# Altium schematic sheet Power_Regulation.SchDoc(Power_Regulation)
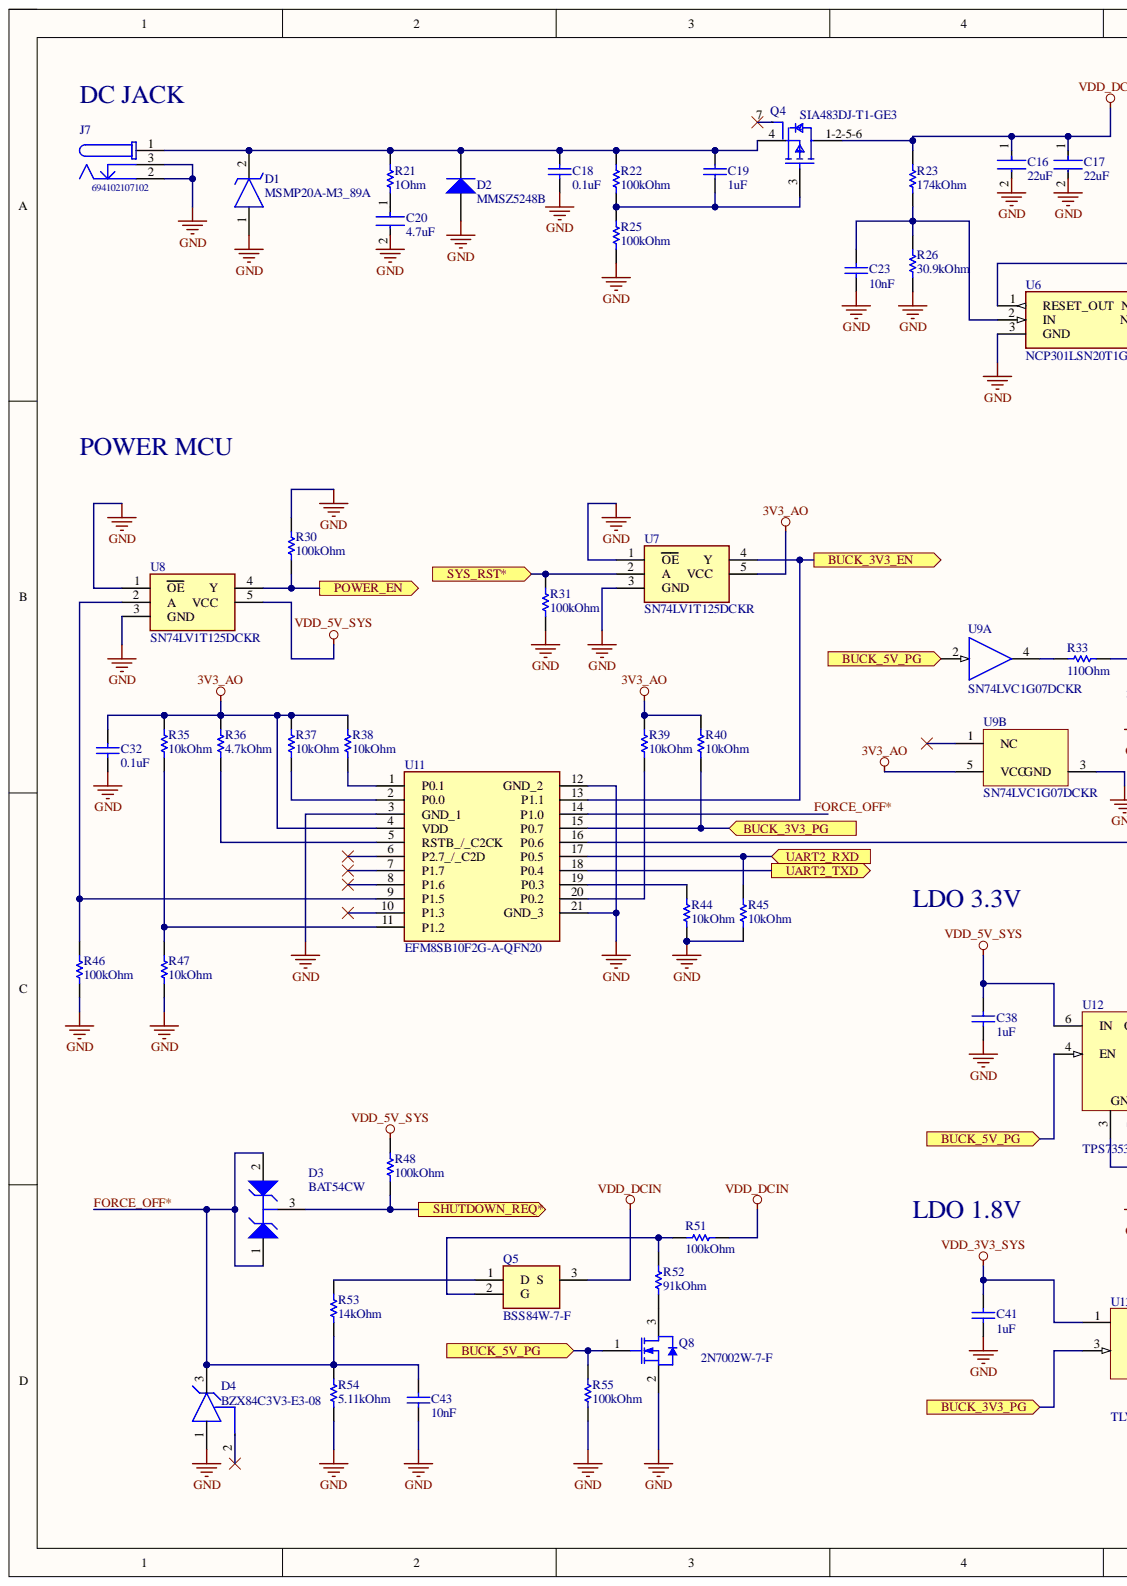
[diagram p0: Power_Regulation.SchDoc, left]
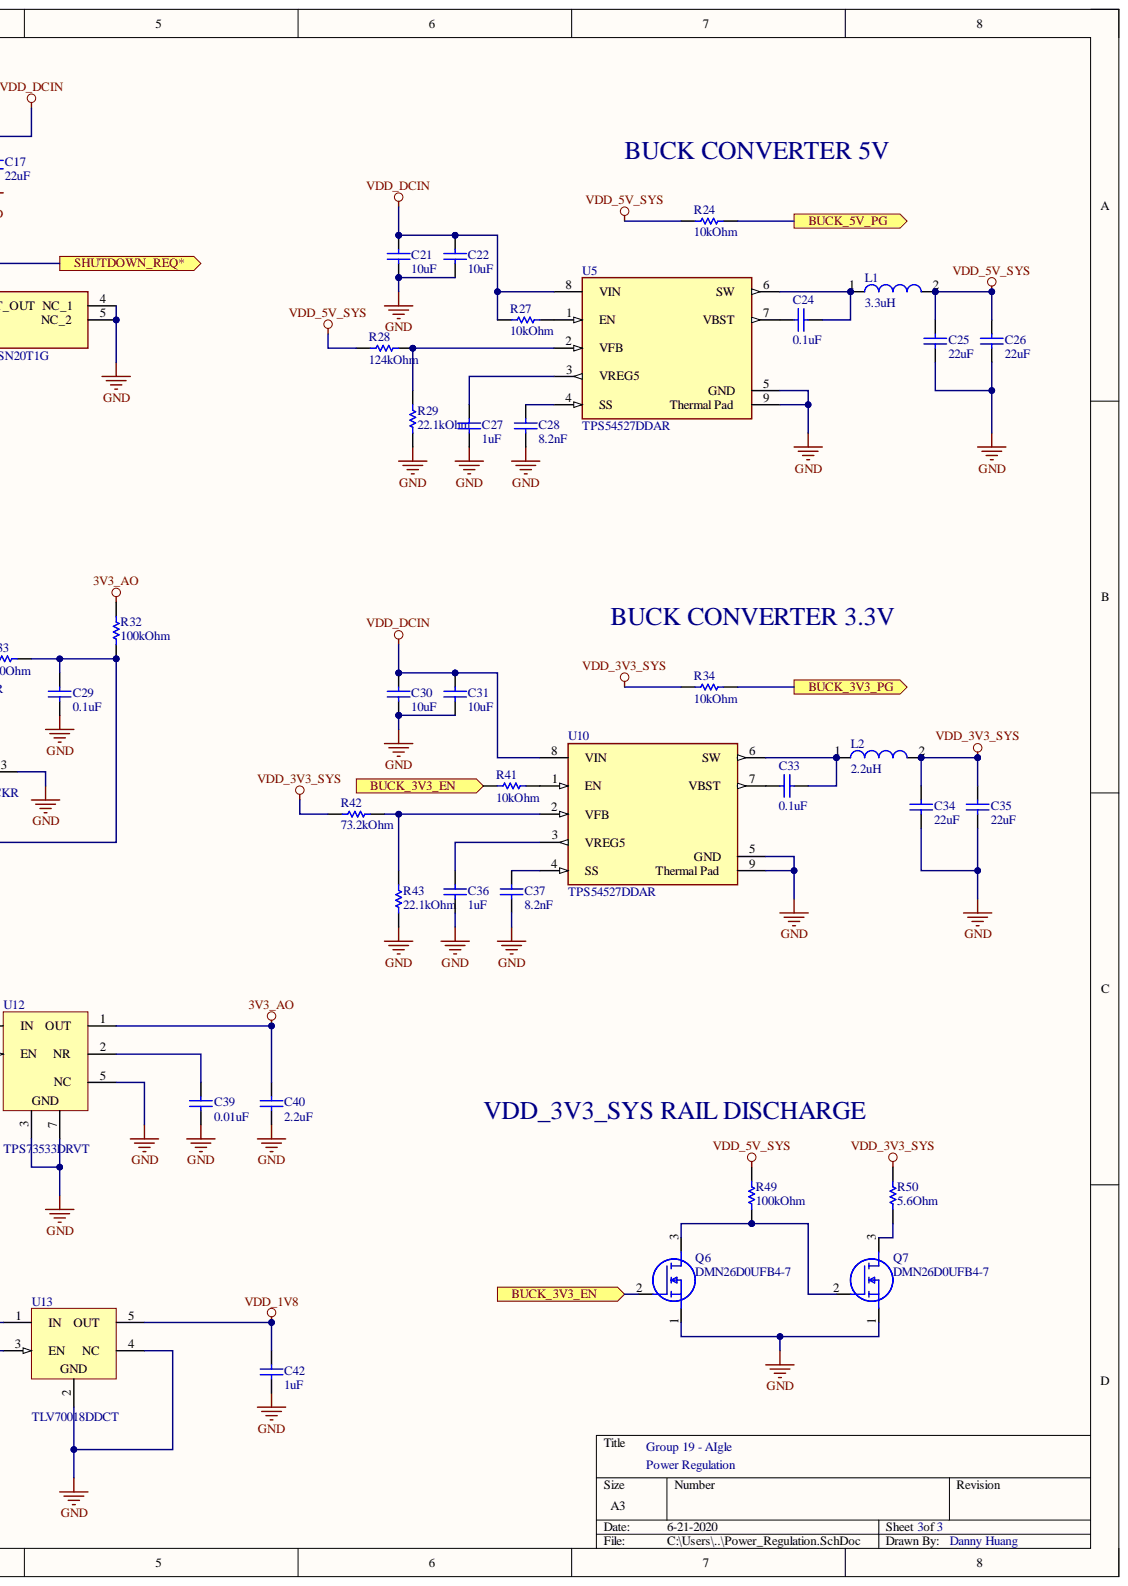
[diagram p1: Power_Regulation.SchDoc, right]

## Parts
C16  22uF  [FP-GRM21-0_2-MFG]
C17  22uF  [FP-GRM21-0_2-MFG]
C18  0.1uF  [CAPC1005X55X30NL05T10]
C19  1uF  [CAPC1608X90X35LL10T15]
C20  4.7uF  [FP-C0603-CG-MFG]
C21  10uF  [CAPC1608X90X35NL10T15]
C22  10uF  [CAPC1608X90X35NL10T15]
C23  10nF  [CAPC1005X55X30NL05T10]
C24  0.1uF  [CAPC1005X55X30NL05T10]
C25  22uF  [CAPC1608X100X20ML10]
C26  22uF  [CAPC1608X100X20ML10]
C27  1uF  [CAPC1005X60X30ML05T10]
C28  8.2nF  [CAPC1005X55X30ML05T10]
C29  0.1uF  [CAPC1005X55X30NL05T10]
C30  10uF  [CAPC1608X90X35NL10T15]
C31  10uF  [CAPC1608X90X35NL10T15]
C32  0.1uF  [CAPC1005X55X30NL05T10]
C33  0.1uF  [CAPC1005X55X30NL05T10]
C34  22uF  [CAPC1608X100X20ML10]
C35  22uF  [CAPC1608X100X20ML10]
C36  1uF  [CAPC1005X60X30ML05T10]
C37  8.2nF  [CAPC1005X55X30ML05T10]
C38  1uF  [CAPC1005X60X30ML05T10]
C39  0.01uF  [CAPC1005X55X30LL05T10]
C40  2.2uF  [CAPC1005X60X30NL05T10]
C41  1uF  [CAPC1005X60X30ML05T10]
C42  1uF  [CAPC1005X60X30ML05T10]
C43  10nF  [CAPC1005X55X30NL05T10]
D1  MSMP20A-M3_89A  [MICROSMP(ESMP)]
D2  MMSZ5248B  [FAIR-SOD-123-2_V]
D3  BAT54CW  [NXP-SOT323-3_L]
D4  BZX84C3V3-E3-08  [FP-6_541-5014_01-4-MFG]
J7  694102107102  [694102107102]
L1  3.3uH  [SMD 0806]
L2  2.2uH  [SMD 0805-Low profile]
Q4  SIA483DJ-T1-GE3  [FP-C-07431-MFG]
Q5  BSS84W-7-F  [SOT65P210X110-3N]
Q6  DMN26D0UFB4-7  [DMN26D0UFB47]
Q7  DMN26D0UFB4-7  [DMN26D0UFB47]
Q8  2N7002W-7-F  [DIOD-SOT323-3_V]
R21  1Ohm  [RESC1005X40X25ML05T05]
R22  100kOhm  [RESC1005X40X25ML05T05]
R23  174kOhm  [RESC1005X40X25LL05T05]
R24  10kOhm  [RESC1005X40X25NL05T05]
R25  100kOhm  [RESC1005X40X25ML05T05]
R26  30.9kOhm  [RESC1005X40X25LL05T05]
R27  10kOhm  [RESC1005X40X25NL05T05]
R28  124kOhm  [RESC1005X40X25ML05T05]
R29  22.1kOhm  [RESC1005X40X25ML05T05]
R30  100kOhm  [RESC1005X40X25ML05T05]
R31  100kOhm  [RESC1005X40X25ML05T05]
R32  100kOhm  [RESC1005X40X25ML05T05]
R33  110Ohm  [RESC1005X40X25LL05T05]
R34  10kOhm  [RESC1005X40X25NL05T05]
R35  10kOhm  [RESC1005X40X25NL05T05]
R36  4.7kOhm  [RESC1005X40X25ML05T05]
R37  10kOhm  [RESC1005X40X25NL05T05]
R38  10kOhm  [RESC1005X40X25NL05T05]
R39  10kOhm  [RESC1005X40X25NL05T05]
R40  10kOhm  [RESC1005X40X25NL05T05]
R41  10kOhm  [RESC1005X40X25NL05T05]
R42  73.2kOhm  [RESC1005X40X25ML05T05]
R43  22.1kOhm  [RESC1005X40X25ML05T05]
R44  10kOhm  [RESC1005X40X25NL05T05]
R45  10kOhm  [RESC1005X40X25NL05T05]
R46  100kOhm  [RESC1005X40X25ML05T05]
R47  10kOhm  [RESC1005X40X25NL05T05]
R48  100kOhm  [RESC1005X40X25ML05T05]
R49  100kOhm  [RESC1005X40X25ML05T05]
R50  5.6Ohm  [RESC1005X40X25ML05T05]
R51  100kOhm  [RESC1005X40X25ML05T05]
R52  91kOhm  [RESC1005X40X25ML05T05]
R53  14kOhm  [RESC1005X40X25LL05T05]
R54  5.11kOhm  [RESC1005X40X25LL05T05]
R55  100kOhm  [RESC1005X40X25ML05T05]
U5  TPS54527DDAR  [FP-DDA0008E-MFG]
U6  NCP301LSN20T1G  [SOT95P275X110-5N]
U7  SN74LV1T125DCKR  [SOT65P210X110-5N]
U8  SN74LV1T125DCKR  [SOT65P210X110-5N]
U9  SN74LVC1G07DCKR  [DCK0005A_M]  (parts A,B)
U10  TPS54527DDAR  [FP-DDA0008E-MFG]
U11  EFM8SB10F2G-A-QFN20  [EFM8SB10F8GAQFN20R]
U12  TPS73533DRVT  [DRV0006A_V]
U13  TLV70018DDCT  [DDC0005A_L]

## Ports
BUCK_3V3_EN, BUCK_3V3_PG, BUCK_5V_PG, POWER_EN, SHUTDOWN_REQ*, SYS_RST*, UART2_RXD, UART2_TXD

## Nets
3V3_AO: C32.1 C40.2 R32.2 R35.2 R36.2 R37.2 R38.2 R39.2 R40.2 U7.5(VCC) U9.5(VCC) U11.4(VDD) U12.1(OUT)
GND: C16.2 C17.2 C18.2 C20.2 C21.1 C22.1 C23.1 C25.1 C26.1 C27.1 C28.1 C29.2 C30.1 C31.1 C32.2 C34.1 C35.1 C36.1 C37.1 C38.1 C39.1 C40.1 C41.1 C42.1 C43.1 D1.1 D2.1 D4.1 J7.2 J7.3 Q6.1 Q7.1 Q8.2 R25.2 R26.1 R29.1 R30.2 R31.1 R43.1 R44.1 R45.1 R46.1 R47.1 R54.1 R55.1 U5.5(GND) U5.9(Thermal_Pad) U6.3(GND) U6.4(NC_1) U6.5(NC_2) U7.1(OE) U7.3(GND) U8.1(OE) U8.3(GND) U9.3(GND) U10.5(GND) U10.9(Thermal_Pad) U11.3(GND_1) U11.12(GND_2) U11.21(GND_3) U12.3 U12.5(NC) U12.7 U13.2 U13.4(NC)
VDD_1V8: C42.2 U13.5(OUT)
VDD_3V3_SYS: C34.2 C35.2 C41.2 L2.2 R34.2 R42.1 R50.2 U13.1(IN)
VDD_5V_SYS: C25.2 C26.2 C38.2 L1.2 R24.2 R28.1 R48.2 R49.2 U8.5(VCC) U12.6(IN)
VDD_DCIN: C16.1 C17.1 C21.2 C22.2 C30.2 C31.2 Q4.1-2-5-6 Q5.3 R23.2 R27.2 R51.1 U5.8(VIN) U10.8(VIN)
BUCK_3V3_EN: Q6.2 R41.2 U7.4(Y) U11.13(P1.1) ; port BUCK_3V3_EN
BUCK_3V3_PG: R34.1 R40.1 U11.15(P0.7) U13.3(EN) ; port BUCK_3V3_PG
BUCK_5V_PG: Q8.1 R24.1 R55.2 U9.2 U12.4(EN) ; port BUCK_5V_PG
FORCE_OFF*: C43.2 D3.1 D3.2 D4.3 R53.1 R54.2 U11.14(P1.0)
POWER_EN: R30.1 U8.4(Y) ; port POWER_EN
SHUTDOWN_REQ*: D3.3 R48.1 U6.1(RESET_OUT) ; port SHUTDOWN_REQ*
SYS_RST*: R31.2 U7.2(A) ; port SYS_RST*
UART2_RXD: R45.2 U11.17(P0.5) ; port UART2_RXD
UART2_TXD: U11.18(P0.4) ; port UART2_TXD
NetC18_1: C18.1 C19.2 D1.2 D2.2 J7.1 Q4.4 R21.2 R22.1
NetC19_1: C19.1 Q4.3 R22.2 R25.1
NetC20_1: C20.1 R21.1
NetC23_2: C23.2 R23.1 R26.2 U6.2(IN)
NetC24_1: C24.1 L1.1 U5.6(SW)
NetC24_2: C24.2 U5.7(VBST)
NetC27_2: C27.2 U5.3(VREG5)
NetC28_2: C28.2 U5.4(SS)
NetC29_1: C29.1 R32.1 R33.2 U11.16(P0.6)
NetC33_1: C33.1 L2.1 U10.6(SW)
NetC33_2: C33.2 U10.7(VBST)
NetC36_2: C36.2 U10.3(VREG5)
NetC37_2: C37.2 U10.4(SS)
NetC39_2: C39.2 U12.2(NR)
NetQ5_1: Q5.1 R53.2
NetQ5_2: Q5.2 R51.2 R52.2
NetQ6_3: Q6.3 Q7.2 R49.1
NetQ7_3: Q7.3 R50.1
NetQ8_3: Q8.3 R52.1
NetR27_1: R27.1 U5.1(EN)
NetR28_2: R28.2 R29.2 U5.2(VFB)
NetR33_1: R33.1 U9.4
NetR35_1: R35.1 R47.2 U11.11(P1.2)
NetR36_1: R36.1 U11.5(RSTB_/_C2CK)
NetR37_1: R37.1 U11.2(P0.0)
NetR38_1: R38.1 U11.1(P0.1)
NetR39_1: R39.1 U11.20(P0.2)
NetR41_1: R41.1 U10.1(EN)
NetR42_2: R42.2 R43.2 U10.2(VFB)
NetR44_2: R44.2 U11.19(P0.3)
NetR46_2: R46.2 U8.2(A) U11.9(P1.5)

Not connected: D4 2; Q4 7; U9 1(NC); U11 6(P2.7_/_C2D) 7(P1.7) 8(P1.6) 10(P1.3)

## Library data of the parts
C16 C17 = CMP-06035-000059-1: CAP CER 22UF 25V X5R 0805; Manufacturer: Murata; Manufacturer Part Number: GRM21BR61E226ME44L; Material: Ceramic; Temperature Coefficient: 15%; Tolerance: 20%; Automotive: No; Capacitance: 22uF; Category: Cap; Component Kind: Standard; ComponentLink2Description: Datasheet; ComponentLink2URL: https://search.murata.co.jp/Ceramy/image/img/A01X/G101/ENG/GRM21BR61E226ME44-01A.pdf; Device Class L1: Passive Components; Device Class L2: Capacitors; Device Class L3: Ceramic Capacitors; Digikey Description: CAP CER 22UF 25V X5R 0805; Height: 1.45mm; Ipc Land Pattern Name: CAPC200125X125; Lead Free: Yes; Library Name: Altium Content Vault; Mouser Description: Multilayer Ceramic Capacitors MLCC - SMD/SMT 0805 22uF 25volts X5R 20%; Octopart: https://octopart.com/grm21br61e226me44l-murata-20025355; Package: GRM21-0.2; RoHS: TRUE; Temperature: -55°C to +85°C; Temperature Characteristic: X5R; Temperature Range High: +85°C; Temperature Range Low: -55°C; Value: 22uF; Voltage Rating: 25V
C18 C24 C29 C32 C33 = CMP-2008-03087-1: Manufacturer: Kemet; Part Number: C0402C104K9RACTU; Component Kind: Standard; Library Name: Altium Content Vault
C19 = CMP-2006-02459-1: Manufacturer: Murata; Part Number: GRM188R61E105KA12D; Component Kind: Standard; Library Name: Altium Content Vault
C20 = CMP-03020-024641-1: CAP CER 4.7UF 6.3V X5R 0603; Manufacturer: Kemet; Manufacturer Part Number: C0603C475K9PACTU; Material: Ceramic; Temperature Coefficient: 15%; Tolerance: 10%; Automotive: No; Capacitance: 4.7uF; Category: Cap; Component Kind: Standard; ComponentLink2Description: Datasheet; ComponentLink2URL: https://content.kemet.com/datasheets/KEM_C1006_X5R_SMD.pdf; Device Class L1: Passive Components; Device Class L2: Capacitors; Device Class L3: Ceramic Capacitors; Digikey Description: CAP CER 4.7UF 6.3V X5R 0603; Height: 0.9mm; Ipc Land Pattern Name: CAPC16080X80; Lead Free: Yes; Library Name: Altium Content Vault; Mouser Description: Multilayer Ceramic Capacitors MLCC - SMD/SMT 6.3volts 4.7uF X5R 10%; Octopart: https://octopart.com/c0603c475k9pactu-kemet-104242; Package: C0603-CG; RoHS: TRUE; Temperature: -55°C to +85°C; Temperature Characteristic: X5R; Temperature Range High: +85°C; Temperature Range Low: -55°C; Value: 4.7uF; Voltage Rating: 6.3V
C21 C22 C30 C31 = CMP-2006-02792-1: Manufacturer: Murata; Part Number: GRM188R60J106ME47D; Component Kind: Standard; Library Name: Altium Content Vault
C23 C43 = CMP-2008-03072-1: Manufacturer: Kemet; Part Number: C0402C103K4RACTU; Component Kind: Standard; Library Name: Altium Content Vault
C25 C26 C34 C35 = CMP-2000-05440-1: Chip Capacitor, 22 uF, +/- 20%, 6.3 V, -55 to 85 degC, 1608 (0603 Metric), RoHS, Tape and Reel; Manufacturer: TDK; PCN Part Number: MLCCPartNumberChange30/Nov/2012; Capacitance: 22µF; Design Resources: 20WNon-IsolatedBuckLEDDriverUsingPLLNK460KG; Kits: 445-9003-KIT-ND-KITCAPCERHICAPMLCCSMD; Online Catalog: CSeries,GeneralPurpose; Other Names: 445-8028-1; Product Training Modules: CSeriesGeneralApplicationsSEAT,CCV,andTVCLDesignToolsGuidetoReplacinganElectrolyticCapacitorwithanMLCC; Temperature Coefficient: X5R; Voltage - Rated: 6.3V; Applications: GeneralPurpose; Category: Capacitors; Component Kind: Standard; Datasheet URL: http://www.farnell.com/datasheets/1913358.pdf; Datasheet Version: Mar-15; Family: CeramicCapacitors; Features: -; Height - Seated (Max): -; Lead Spacing: -; Lead Style: -; Library Name: Altium Content Vault; Manufacturer URL: http://www.tdk.com/; Mounting Type: SurfaceMount,MLCC; Operating Temperature: -55degC~85degC; Package / Case: 0603(1608Metric); Package Description: 2-Pin Surface Mount Device, Body 1.6 x 0.8 mm; Package Reference: 603; Packaging: CutTape(CT); Ratings: -; RoHS Information: RoHSCertificate-MLCC; Series: C; Size / Dimension: 0.063"Lx0.031"W(1.60mmx0.80mm); Standard Package: 1; Thickness (Max): 0.039"(1.00mm); Tolerance: ±20%
C27 C36 C38 C41 C42 = CMP-2008-02075-1: Manufacturer: Kemet; Part Number: C0402C105K9PAC7867; Component Kind: Standard; Library Name: Altium Content Vault
C28 C37 = CMP-2008-03262-1: Manufacturer: Kemet; Part Number: C0402C822K5RACTU; Component Kind: Standard; Library Name: Altium Content Vault
C39 = CMP-2008-03076-1: Manufacturer: Kemet; Part Number: C0402C103K9RACTU; Component Kind: Standard; Library Name: Altium Content Vault
C40 = CMP-2008-02055-1: Manufacturer: Kemet; Part Number: C0402C225K9PACTU; Component Kind: Standard; Library Name: Altium Content Vault
D1 = MSMP20A-M3_89A: TVS Diode (Uni-directional); Manufacturer_Name: Vishay; Manufacturer_Part_Number: MSMP20A-M3/89A; Arrow Part Number: MSMP20A-M3/89A; Arrow Price/Stock: https://www.arrow.com/en/products/msmp20a-m389a/vishay; Component Kind: Standard; Datasheet Link: https://www.vishay.com/docs/89457/msmp6athrumsmp20a.pdf; Mouser Part Number: 78-MSMP20A-M389A; Mouser Price/Stock: https://www.mouser.com/Search/Refine.aspx?Keyword=78-MSMP20A-M389A
D2 = CMP-2000-06744-1: Zener Diode, 18 V, -55 to 150 degC, 2-Pin SOD-123, RoHS, Tape and Reel; Manuf. Code: FSC; Manufacturer: FairchildSemiconductor; Configuration: 1diode; Enclosure: SOD123; Forward voltage reference: 10mA; Impedance: 21Ω; Impedance reference: 7mA; Max. power: 500mW; Residual reverse leakage current: 0.1µA; UF: 900mV; Uz (max.): 18.9V; Uz(min.): 17.1V; Zener voltage: 18V; Category: Zenerdiode; Component Kind: Standard; Datasheet URL: https://www.fairchildsemi.com/datasheets/MM/MMSZ5226B.pdf; Datasheet Version: Rev. 1.6, 10/2015; Library Name: Altium Content Vault; Manufacturer URL: https://www.fairchildsemi.com/; Max. operating temperature: +150°C; Mounting Technology: SurfaceMount; Mounting type: Surface-mount; Operating temperature (min.): -55°C; Package Description: 2-Pin Surface Mount Device, Body 2.7 x 1.6 mm; Package Reference: SOD-123-2; Type: MMSZ5248B
D3 = CMP-0417-00001-1: SOT-323 Plastic-Encapsulated Diode; Manufacturer: NXP Semiconductors; Max Forward Current: 200 mA; Non-repetitive Peak Reverse Current: 600 mA; Component Kind: Standard; ComponentLink1Description: Manufacturer URL; ComponentLink1URL: http://www.nxp.com/; ComponentLink2Description: Datasheet; ComponentLink2URL: http://www.nxp.com/documents/data_sheet/BAT54W_SER.pdf; ComponentLink3Description: Package Specification; ComponentLink3URL: http://www.nxp.com/documents/outline_drawing/sot323_po.pdf; DatasheetVersion: Rev. 3, 11/2012; Library Name: Altium Content Vault; Mounting Technology: Surface Mount; PackageDescription: 3-Pin SOT323, 2 x 1.3 mm Body, 0.65 mm Pitch; PackageReference: SOT323-3; PackageVersion: Mar-06; PartNumber: BAT54CW; Storage Temperature: -65 to 150 degC
D4 = CMP-02541-000017-1: DIODE ZENER 3.3V 300MW SOT23; Manufacturer: Vishay; Max Junction Temp: +150°C; Max Reverse Leakage Current: 5uA; Max Zener Voltage: 3.5V; Reverse Voltage: 1V; Zener Impedance: 95Ω; Automotive: No; Category: Diode; Component Kind: Standard; ComponentLink2Description: Datasheet; ComponentLink2URL: http://www.vishay.com/docs/85763/bzx84v.pdf; Device Class L1: Discrete Semiconductors; Device Class L2: Diodes; Device Class L3: Zener Diodes; Digikey Description: DIODE ZENER 3.3V 300MW SOT23; Height: 1.15mm; Lead Free: Yes; Library Name: Altium Content Vault; Manufacturer Part Number: BZX84C3V3-E3-08; Mouser Description: Zener Diodes 3.3 Volt 0.35W 5%; Nom Zener Voltage: 3.3V; Octopart: https://octopart.com/bzx84c3v3-e3-08-vishay-30324846; Package: 6.541-5014.01-4; Power Dissipation: 300mW; RoHS: TRUE; Temperature: -55°C to +150°C; Temperature Range High: +150°C; Temperature Range Low: -55°C
J7 = CMP-1502-00174-1: DC Power Jack Connector Low Profile with 4 soldering pads & Pegs WR-DC, Male, Right Angled, SMT, 5 A, 18 V; Manufacturer: Wurth Elektronik; Opening Diameter: 3.9mm; Plug Inner Diameter: 1.05mm; Plug Outer Diameter: 3.5mm; Rated Current: 5A; Working Voltage: 18V; Component Kind: Standard; Datasheet URL: http://katalog.we-online.de/em/datasheet/6941xx107102.pdf; Gender: Male; Library Name: Altium Content Vault; Manufacturer URL: http://www.we-online.de; Mounting Technology: SMT; Operating Temperature: -40to85degC; Orientation: RightAngled; Package Reference: 694102107102; Packaging: TapeandReel; Part Number: 694102107102; Publisher: WurthElektronik
L1 = CMP-1392-00058-1: Power Multilayer Inductor WE-PMI, L=3.30 µH; Manufacturer: Wuerth Elektronik; PartNumber: 74479776233; Inductance: 3.30 ÂµH; Component Kind: Standard; ComponentLink1Description: Manufacturer URL; ComponentLink1URL: http://www.we-online.com; ComponentLink2Description: Datasheet; ComponentLink2URL: http://katalog.we-online.de/kataloge/eisos/media/pdf/74479776233A.pdf; Library Name: Altium Content Vault; PackageReference: SMD 0806
L2 = CMP-1392-00044-1: Power Multilayer Inductor WE-PMI, L=2.20 µH; Manufacturer: Wuerth Elektronik; PartNumber: 74479774222; Inductance: 2.20 ÂµH; Component Kind: Standard; ComponentLink1Description: Manufacturer URL; ComponentLink1URL: http://www.we-online.com; ComponentLink2Description: Datasheet; ComponentLink2URL: http://katalog.we-online.de/kataloge/eisos/media/pdf/74479774222.pdf; Library Name: Altium Content Vault; PackageReference: SMD 0805-Low profile
Q4 = CMP-02550-000185-1: MOSFET P-CH 30V 12A SC70-6; Manufacturer: Vishay; Continuous Drain Current: -10A; Depletion Mode: False; Drain To Source Breakdown Voltage: -30V; Drain To Source Resistance: 0.016Ω; Gate Charge At Vgs: 29nC @ -10V; Gate To Source Voltage: 20V; Input Capacitace At Vds: 1550pF @ -15V; Max Forward Diode Voltage: -1.2V; Max Junction Temp: +150°C; Number Of Channels: 1; Power Dissipation: 3.5W; Pulse Drain Current: -40A; Reverse Recovery Charge: 10nC; Reverse Recovery Time: 17ns; Rthja Max: 28°C/W; Standoff Height: 0mm; Threshold Vgs Max: -2.2V; Threshold Vgs Min: -1V; Transconductance: 23S; Turn Off Delay Time: 27ns; Turn On Delay Time: 10ns; Typ Forward Diode Voltage: -0.8V; Automotive: No; Category: Trans; Component Kind: Standard; ComponentLink2Description: Datasheet; ComponentLink2URL: http://www.vishay.com/docs/62779/sia483dj.pdf; Device Class L1: Discrete Semiconductors; Device Class L2: Transistors; Device Class L3: MOSFETs; Digikey Description: MOSFET P-CH 30V 12A SC70-6; Drain To Source Voltage: -30V; Height: 0.8mm; Lead Free: Yes; Library Name: Altium Content Vault; Manufacturer Part Number: SIA483DJ-T1-GE3; Mouser Description: MOSFET -30V 21mOhm@10V 12A P-Ch G-III; Number Of P Channels: 1; Octopart: https://octopart.com/sia483dj-t1-ge3-vishay-45727624; Package: C-07431; RoHS: TRUE; Temperature: -55°C to +150°C; Temperature Range High: +150°C; Temperature Range Low: -55°C
Q5 = BSS84W-7-F: Undefined or Miscellaneous; Manufacturer_Name: Diodes Inc.; Arrow Part Number: BSS84W-7-F; Arrow Price/Stock: https://www.arrow.com/en/products/bss84w-7-f/diodes-incorporated; Component Kind: Standard; Datasheet Link: http://componentsearchengine.com/Datasheets/1/BSS84W-7-F.pdf; Manufacturer_Part_Number: BSS84W-7-F; Mouser Part Number: 621-BSS84W-F; Mouser Price/Stock: https://www.mouser.co.uk/ProductDetail/Diodes-Incorporated/BSS84W-7-F?qs=C%2FiXDRqWM5gURToIay7bAQ%3D%3D
Q6 Q7 = DMN26D0UFB4-7: MOSFET (N-Channel); Manufacturer_Name: Diodes Inc.; Arrow Part Number: DMN26D0UFB4-7; Arrow Price/Stock: https://www.arrow.com/en/products/dmn26d0ufb4-7/diodes-incorporated; Component Kind: Standard; Datasheet Link: https://componentsearchengine.com/Datasheets/1/DMN26D0UFB4-7.pdf; Height: 0.4mm; Manufacturer_Part_Number: DMN26D0UFB4-7; Mouser Part Number: 621-DMN26D0UFB4-7; Mouser Price/Stock: https://www.mouser.co.uk/ProductDetail/Diodes-Incorporated/DMN26D0UFB4-7?qs=GWgl%252BsiMZr%252B4pznsTuF3iQ%3D%3D
Q8 = CMP-2000-05568-1: N-Channel Enhancement Mode Field Effect Transistor, 60 V (VDS), 0.115 A (IDS), -55 to 150 degC, 3-Pin SOT323, RoHS, Tape and Reel; Brand: DiodesIncorporated; Configuration: Single; Forward Transconductance - Min: 0.08S; Id - Continuous Drain Current: 115mA; Number of Channels: 1Channel; Pd - Power Dissipation: 200mW; Rds On - Drain-Source Resistance: 2.6Ohms; Transistor Type: 1N-Channel; Typical Turn-Off Delay Time: 11ns; Typical Turn-On Delay Time: 7ns; Unit Weight: 0.000212oz; Vds - Drain-Source Breakdown Voltage: 70V; Vgs - Gate-Source Voltage: 20V; Channel Mode: Enhancement; Component Kind: Standard; Datasheet URL: http://www.diodes.com/_files/datasheets/ds30099.pdf; Datasheet Version: Rev. 14-2, 09/2013; Factory Pack Quantity: 3000; Height: 1mm; Length: 2.2mm; Library Name: Altium Content Vault; Manufacturer: DiodesIncorporated; Manufacturer URL: http://www.diodes.com/; Maximum Operating Temperature: +150C; Minimum Operating Temperature: -55C; Mounting Style: SMD/SMT; Mounting Technology: SurfaceMount; Package / Case: SOT-323-3; Package Description: 3-Pin Small Outline Transistor, Body 2 x 1.25 mm, Pitch 0.65 mm; Package Reference: SOT323; Packaging: Reel; Product: MOSFETSmallSignal; Product Category: MOSFET; RoHS: True; Series: 2N7002; Technology: Si; Transistor Polarity: N-Channel; Type: EnhancementModeFieldEffectTransistor; Width: 1.35mm
R21 = CMP-2002-08342-1: Manufacturer: Vishay Dale; Part Number: CRCW04021R00FKED; Component Kind: Standard; Library Name: Altium Content Vault
R22 R25 R30-R32 R46 R48 R49 R51 R55 = CMP-2002-08123-2: Chip Resistor, 100 KOhm, +/- 1%, 0.63 W, -55 to 155 degC, 0402 (1005 Metric), RoHS, Tape and Reel; Case\Package: 0402; Power Rating: 63 mW; Type (Resistor): Thick Film; Voltage Rating: 50 V; Case Code (Imperial): 0402; Case Code (Metric): 1005; Component Kind: Standard; ComponentLink1Description: Manufacturer URL; ComponentLink1URL: http://www.vishay.com/; ComponentLink2Description: Datasheet; ComponentLink2URL: http://www.vishay.com/docs/20035/dcrcwe3.pdf; DatasheetVersion: 06/2012; Height: 0.35 mm; Length: 1 mm; Library Name: Altium Content Vault; Max Operating Temperature: 155 degC; Min Operating Temperature: -55 degC; Mount: Surface Mount; Mounting Technology: Surface Mount; Package Quantity: 10000; PackageDescription: 2-Pin Surface Mount Device, Body 1 x 0.5 mm; PackageReference: 0402; Packaging: Tape and Reel; Pins: 2; Radiation Hardening: No; REACH SVHC: Unknown; Resistance: 100 kohm; RoHSCompliant: true; Tolerance: 1%; Weight: 2.3E-05 oz; Width: 0.5 mm
R23 = CMP-2002-08244-1: Manufacturer: Vishay Dale; Part Number: CRCW0402174KFKED; Component Kind: Standard; Library Name: Altium Content Vault
R24 R27 R34 R41 = CMP-2002-08136-1: Manufacturer: Vishay Dale; Part Number: CRCW040210K0JNED; Component Kind: Standard; Library Name: Altium Content Vault
R26 = CMP-2002-08508-1: Manufacturer: Vishay Dale; Part Number: CRCW040230K9FKED; Component Kind: Standard; Library Name: Altium Content Vault
R28 = CMP-2002-08173-1: Manufacturer: Vishay Dale; Part Number: CRCW0402124KFKED; Component Kind: Standard; Library Name: Altium Content Vault
R29 R43 = CMP-2002-08380-1: Manufacturer: Vishay Dale; Part Number: CRCW040222K1FKED; Component Kind: Standard; Library Name: Altium Content Vault
R33 = CMP-2002-08151-1: Manufacturer: Vishay Dale; Part Number: CRCW0402110RJNED; Component Kind: Standard; Library Name: Altium Content Vault
R35 R37-R40 R44 R45 R47 = CMP-2002-08135-1: Manufacturer: Vishay Dale; Part Number: CRCW040210K0FKED; Component Kind: Standard; Library Name: Altium Content Vault
R36 = CMP-2002-00053-1: Manufacturer: Vishay BCcomponents; Part Number: CRCW04024K70JNED; Component Kind: Standard; Library Name: Altium Content Vault
R42 = CMP-2002-00203-1: Manufacturer: Vishay Dale; Part Number: CRCW040273K2FKED; Component Kind: Standard; Library Name: Altium Content Vault
R50 = CMP-2002-00563-1: Manufacturer: Panasonic; Part Number: ERJ-2GEJ5R6X; Component Kind: Standard; Library Name: Altium Content Vault
R52 = CMP-2002-00271-1: Manufacturer: Vishay Dale; Part Number: CRCW040291K0JNED; Component Kind: Standard; Library Name: Altium Content Vault
R53 = CMP-2002-08207-1: Manufacturer: Vishay Dale; Part Number: CRCW040214K0FKED; Component Kind: Standard; Library Name: Altium Content Vault
R54 = CMP-2002-00117-1: Manufacturer: Vishay Dale; Part Number: CRCW04025K11FKED; Component Kind: Standard; Library Name: Altium Content Vault
U5 U10 = CMP-04918-000091-1: IC REG BUCK ADJUSTABLE 5A 8SOPWR; Manufacturer: Texas Instruments; Max Duty Cycle: 85%; Max Junction Temp: +150°C; Max Supply Voltage: 18V; Min Supply Voltage: 4.5V; Nominal Supply Current: 900uA; Number Of Outputs: 1; Output Current: 5mA; Output Type: AdjustableProgrammable; Output Voltage: 0.76-6V; Standoff Height: 0mm; Switching Frequency: 650kHz; Ambient Temperature Range High: +85°C; Ambient Temperature Range Low: -40°C; Automotive: No; Category: IC; Component Kind: Standard; ComponentLink2Description: Datasheet; ComponentLink2URL: http://www.ti.com/lit/ds/symlink/tps54527.pdf; Device Class L1: Integrated Circuits (ICs); Device Class L2: Power Management ICs; Device Class L3: Voltage Regulators - Switching; Digikey Description: IC REG BUCK ADJUSTABLE 5A 8SOPWR; Height: 1.7mm; Ipc Land Pattern Name: SOP127P600X170-8; Lead Free: Yes; Library Name: Altium Content Vault; Manufacturer Part Number: TPS54527DDAR; Mouser Description: 4.5V to 18V Input, 5-A Synchronous Step-Down Converter 8-SO PowerPAD -40 to 85; Octopart: https://octopart.com/tps54527ddar-texas+instruments-20362751; Package: DDA0008E; RoHS: TRUE; Switching Topology: Buck; Temperature: -40°C to +85°C; Temperature Range High: +85°C; Temperature Range Low: -40°C
U6 = NCP301LSN20T1G: Integrated Circuit; Manufacturer_Name: ON Semiconductor; Arrow Part Number: NCP301LSN20T1G; Arrow Price/Stock: https://www.arrow.com/en/products/ncp301lsn20t1g/on-semiconductor; Component Kind: Standard; Datasheet Link: http://www.onsemi.com/pub/Collateral/NCP300-D.PDF; Height: 1.1mm; Manufacturer_Part_Number: NCP301LSN20T1G; Mouser Part Number: 863-NCP301LSN20T1G; Mouser Price/Stock: https://www.mouser.co.uk/ProductDetail/ON-Semiconductor/NCP301LSN20T1G?qs=%2FugrpAKHX8q7C9Mzamhz3w%3D%3D
U7 U8 = SN74LV1T125DCKR: Integrated Circuit; Manufacturer_Name: Texas Instruments; Arrow Part Number: SN74LV1T125DCKR; Arrow Price/Stock: https://www.arrow.com/en/products/sn74lv1t125dckr/texas-instruments; Component Kind: Standard; Datasheet Link: http://www.ti.com/lit/gpn/sn74lv1t125; Height: 1.1mm; Manufacturer_Part_Number: SN74LV1T125DCKR; Mouser Part Number: 595-SN74LV1T125DCKR; Mouser Price/Stock: https://www.mouser.co.uk/ProductDetail/Texas-Instruments/SN74LV1T125DCKR?qs=8sOby8ZxZLEEpIbhoTKj7w%3D%3D
U9 = CMP-0859-00290-3: Single Buffer/Driver With Open-Drain Output, DCK0005A, LARGE T&R; Manufacturer: Texas Instruments; 3-State Output: No; Bits(#): 1; F @ Nom Voltage(Max)(Mhz): 150; ICC @ Nom Voltage(Max)(mA): 0.01; Input Type: CMOS/TTL; Logic: True; Output Drive (IOL/IOH)(Max)(mA): 32/-32; Output Type: CMOS; Rating: Catalog; Sub-Family: Non-Inverting Buffer/Driver; Technology Family: LVC; tpd @ Nom Voltage(Max)(ns): 8.6,6,4.7,4; VCC(Max)(V): 5.5; VCC(Min)(V): 1.65; Voltage(Nom)(V): 1.8,2.5,3.3,5; Component Kind: Standard; DatasheetVersion: SCES296AC; Generic Part Number: SN74LVC1G07; Library Name: Altium Content Vault; Operating Temperature Range(C): -40 to 125,-40 to 85; PackageReference: DCK0005A; Schmitt Trigger: No
U11 = EFM8SB10F2G-A-QFN20: Integrated Circuit; Manufacturer_Name: Silicon Labs; Arrow Part Number: EFM8SB10F2G-A-QFN20; Arrow Price/Stock: https://www.arrow.com/en/products/efm8sb10f2g-a-qfn20/silicon-labs; Component Kind: Standard; Datasheet Link: https://www.silabs.com/documents/public/data-sheets/efm8sb1-datasheet.pdf; Height: 0.6mm; Manufacturer_Part_Number: EFM8SB10F2G-A-QFN20; Mouser Part Number: 634-8SB10F2GAQF20; Mouser Price/Stock: https://www.mouser.co.uk/ProductDetail/Silicon-Labs/EFM8SB10F2G-A-QFN20?qs=DCjbIwhU0Zv4HMgdSm4N7w%3D%3D
U12 = CMP-0395-00672-2: Single Output High PSRR LDO, 500 mA, Fixed 3.3 V Output, 2.7 to 6.5 V Input, with Low IQ, 6-pin SON (DRV), -40 to 125 degC, Green (RoHS & no Sb/Br); Manufacturer: Texas Instruments; Accuracy(%): 2; Fixed Output Options(V): 3.3; Iout(Max)(A): 0.5; Iq(Typ)(mA): 0.04; SubFamily: Single Channel LDO; Vdo(Typ)(mV): 280; Vin(Max)(V): 6.5; Vin(Min)(V): 2.7; Component Kind: Standard; ComponentLink1Description: Manufacturer URL; ComponentLink1URL: http://www.ti.com/; ComponentLink2Description: Datasheet; ComponentLink2URL: http://www.ti.com/general/docs/lit/getliterature.tsp?genericPartNumber=TPS73533&fileType=pdf; ComponentLink3Description: Package Specification; ComponentLink3URL: http://www.ti.com/litv/pdf/mpds216d; DatasheetVersion: SBVS087J; Eco-Plan: Green (RoHS & no Sb/Br); Library Name: Altium Content Vault; Mounting Technology: Surface Mount; PackageDescription: 6-Pin Plastic Small Outline No-Lead, Body 2 x 2 mm, Pitch 0.65 mm; PackageReference: DRV0006A; PackageVersion: 4206925/E; PartNumber: TPS73533DRVT; Vout Adj(Max)(V): 3.3; Vout Adj(Min)(V): 3.3
U13 = CMP-0394-01053-2: Single Output LDO, 200 mA, Fixed 1.8 V Output, 2 to 5.5 V Input, with Low IQ, 5-pin SOT (DDC), -40 to 125 degC, Green (RoHS & no Sb/Br); Manufacturer: Texas Instruments; Accuracy(%): 2; Fixed Output Options(V): 1.8; Iout(Max)(A): 0.2; Iq(Typ)(mA): 0.03; SubFamily: Single Channel LDO; Vdo(Typ)(mV): 175; Vin(Max)(V): 5.5; Code_JEDEC: MO-193; Component Kind: Standard; ComponentLink1Description: Manufacturer URL; ComponentLink1URL: http://www.ti.com/; ComponentLink2Description: Datasheet; ComponentLink2URL: http://www.ti.com/general/docs/lit/getliterature.tsp?genericPartNumber=TLV70018&fileType=pdf; ComponentLink3Description: Package Specification; ComponentLink3URL: http://www.ti.com/litv/pdf/mpds123d; DatasheetVersion: SLVSA00D; Eco-Plan: Green (RoHS & no Sb/Br); Library Name: Altium Content Vault; Mounting Technology: Surface Mount; PackageDescription: 5-Pin Plastic Small Outline, Body 2.9 x 1.6 mm, 0.95 mm Pitch; PackageReference: DDC0005A; PackageVersion: 4204403-2/E; PartNumber: TLV70018DDCT; Vin(Min)(V): 2; Vout Adj(Max)(V): 1.8; Vout Adj(Min)(V): 1.8
